# Altium schematic sheet 2_CON_FMC.SchDoc
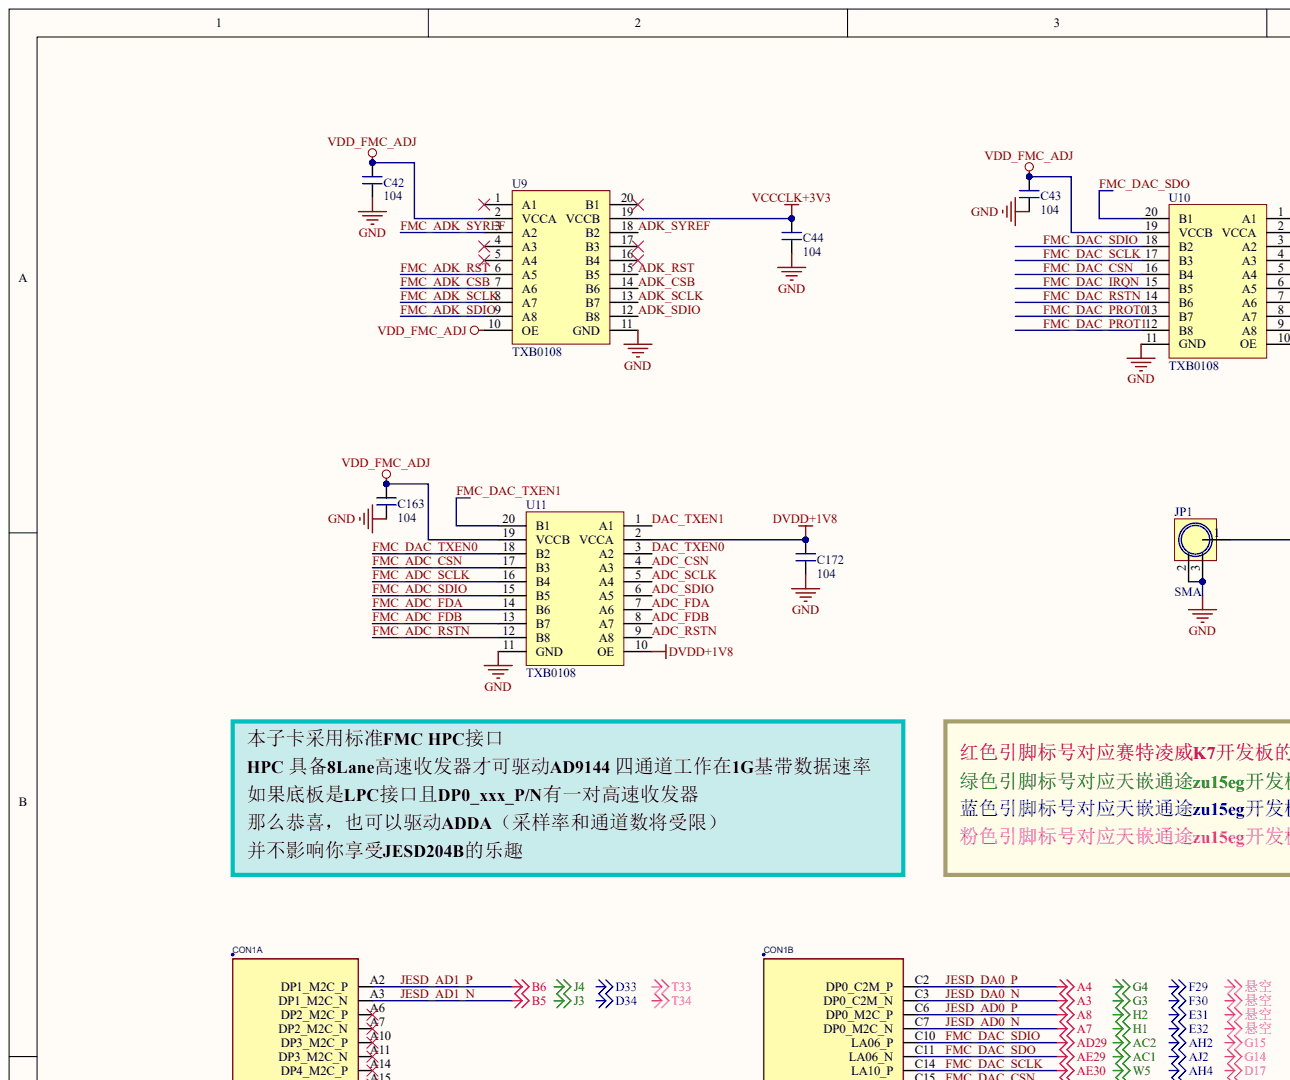
[diagram p0: 2_CON_FMC.SchDoc, top left]
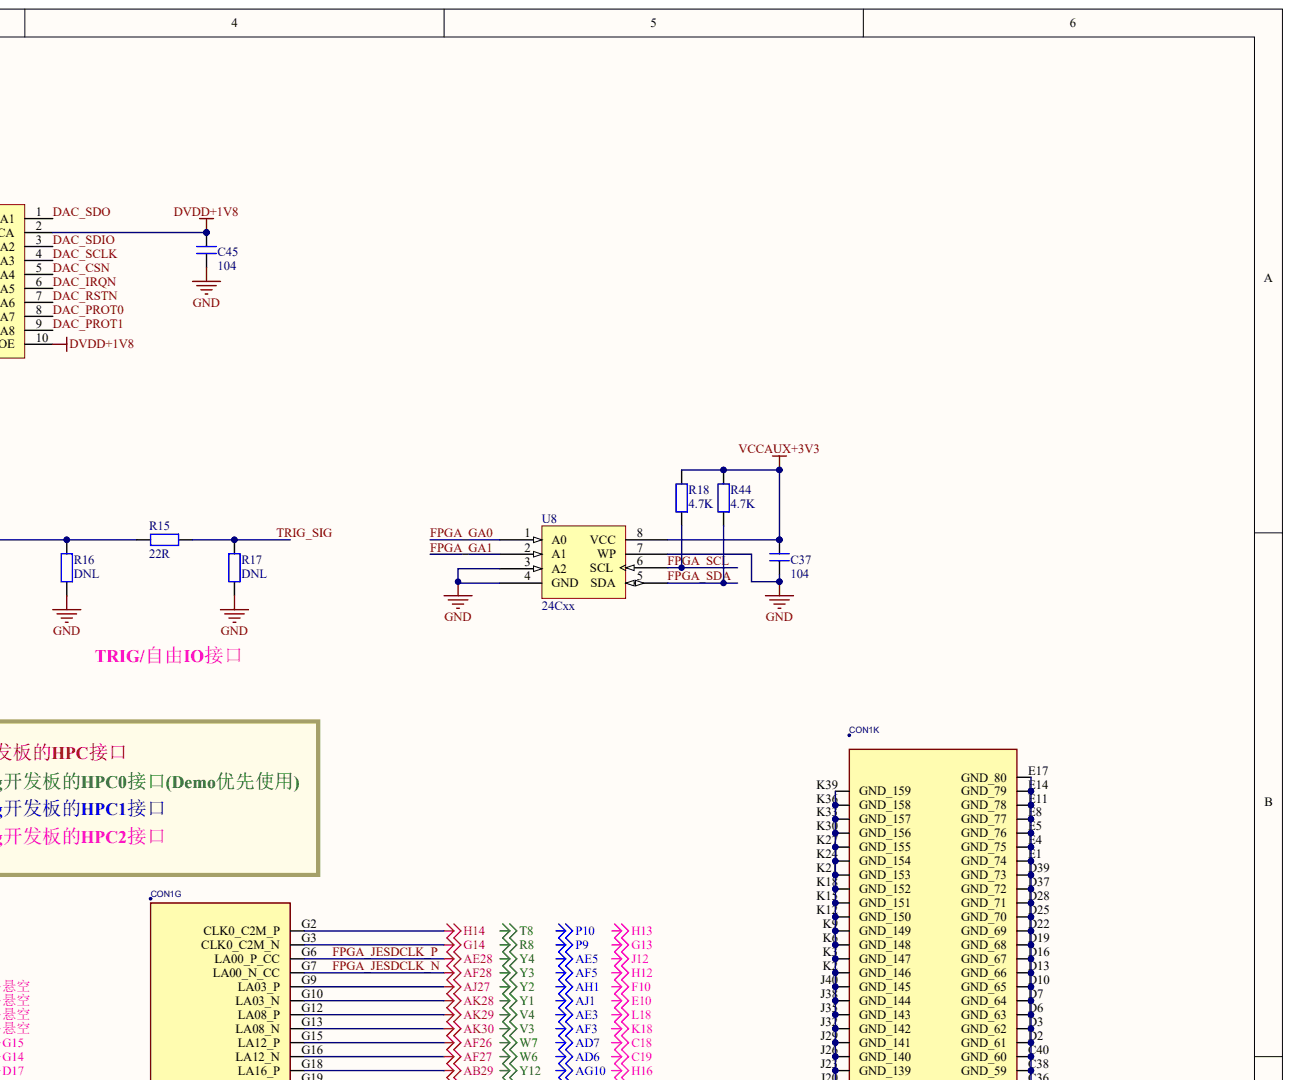
[diagram p1: 2_CON_FMC.SchDoc, top right]
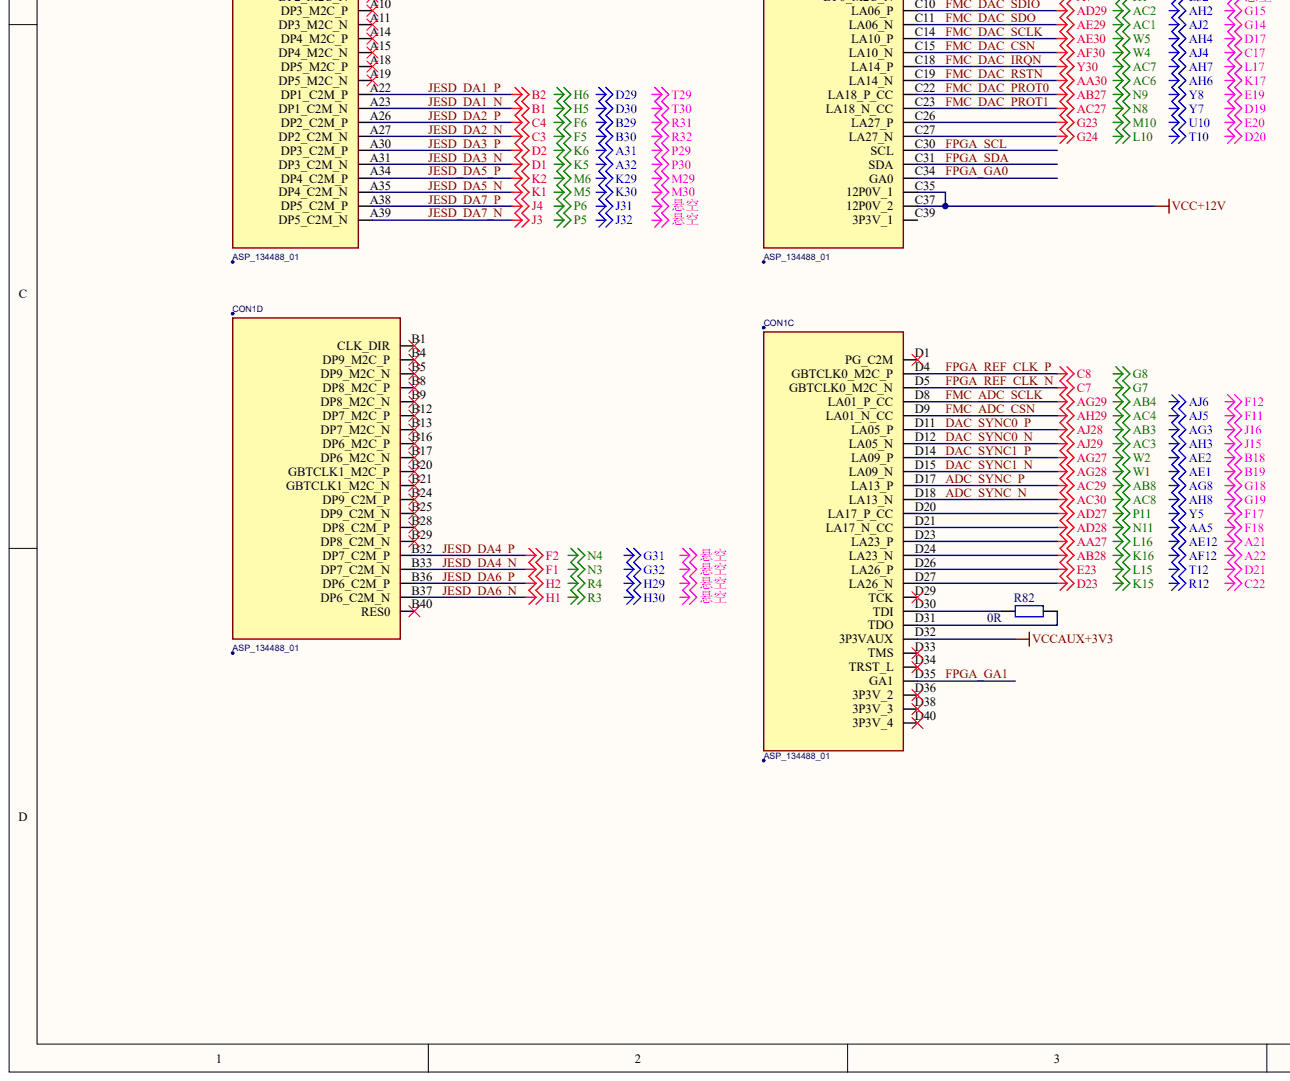
[diagram p2: 2_CON_FMC.SchDoc, bottom left]
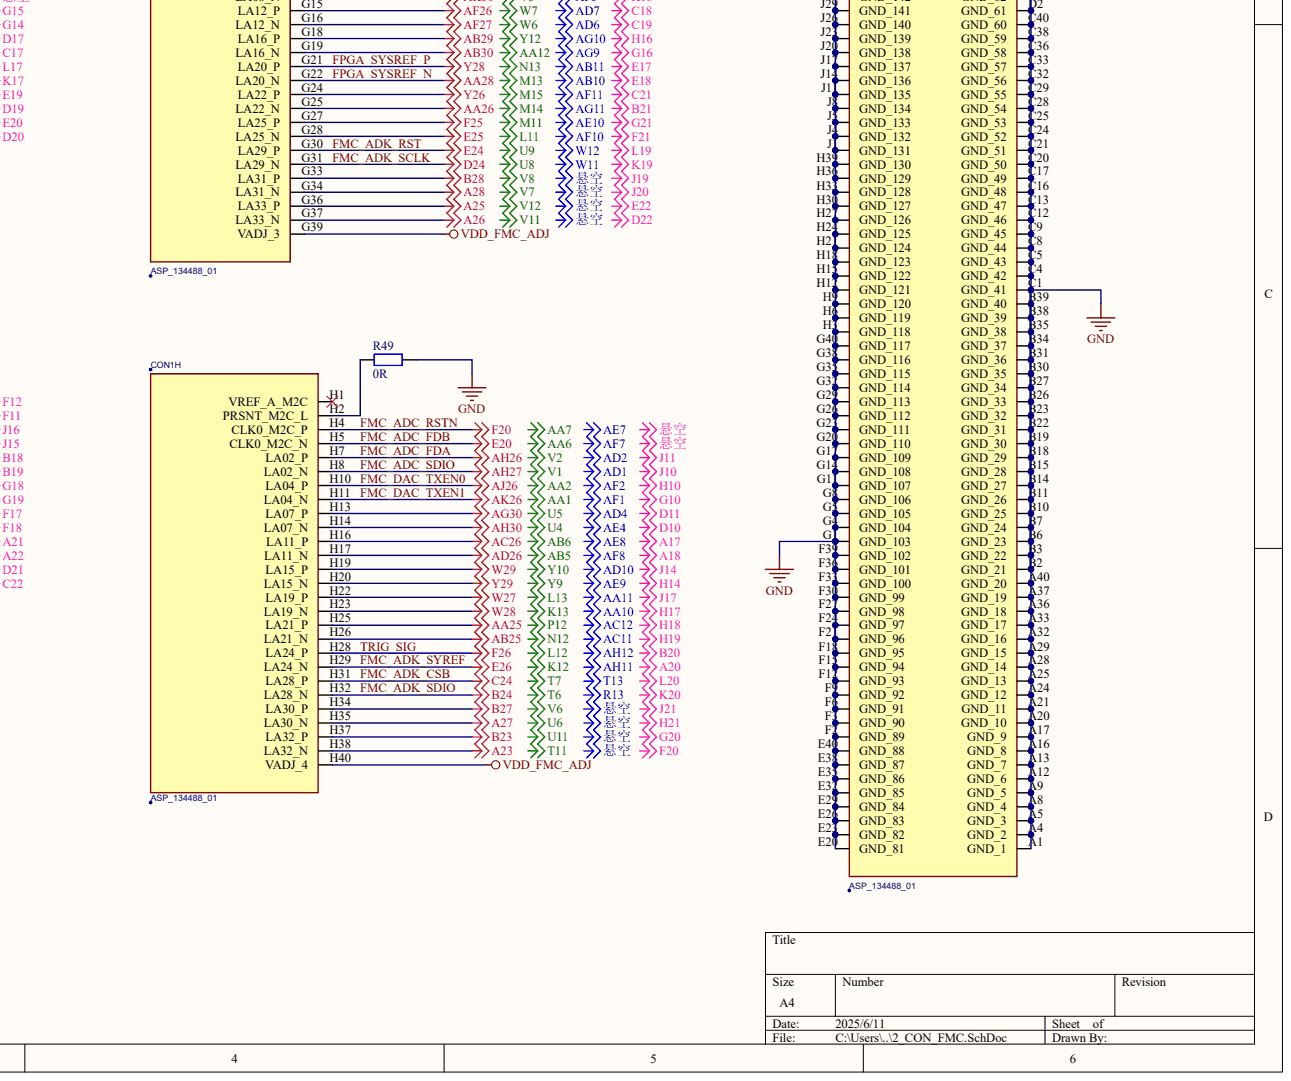
[diagram p3: 2_CON_FMC.SchDoc, bottom right]

## Parts
C37  104
C42  104
C43  104
C44  104
C45  104
C163  104
C172  104
CON1  ASP_134488_01  (parts A,B,C,D,G,H,K)
JP1  SMA
R15  22R
R16  DNL
R17  DNL
R18  4.7K
R44  4.7K
R49  0R
R82  0R
U8  24Cxx
U9  TXB0108
U10  TXB0108
U11  TXB0108

## Nets
A23: CON1.H38(LA32_N)
A25: CON1.G36(LA33_P)
A26: CON1.G37(LA33_N)
A27: CON1.H35(LA30_N)
A28: CON1.G34(LA31_N)
AA25: CON1.H25(LA21_P)
AA26: CON1.G25(LA22_N)
AA27: CON1.D23(LA23_P)
AB25: CON1.H26(LA21_N)
AB28: CON1.D24(LA23_N)
AB29: CON1.G18(LA16_P)
AB30: CON1.G19(LA16_N)
AC26: CON1.H16(LA11_P)
AD26: CON1.H17(LA11_N)
AD27: CON1.D20(LA17_P_CC)
AD28: CON1.D21(LA17_N_CC)
AF26: CON1.G15(LA12_P)
AF27: CON1.G16(LA12_N)
AG30: CON1.H13(LA07_P)
AH30: CON1.H14(LA07_N)
AJ27: CON1.G9(LA03_P)
AK28: CON1.G10(LA03_N)
AK29: CON1.G12(LA08_P)
AK30: CON1.G13(LA08_N)
B23: CON1.H37(LA32_P)
B27: CON1.H34(LA30_P)
B28: CON1.G33(LA31_P)
D23: CON1.D27(LA26_N)
DVDD+1V8: C45.1 C172.1 U10.2(VCCA) U10.10(OE) U11.2(VCCA) U11.10(OE)
E23: CON1.D26(LA26_P)
E25: CON1.G28(LA25_N)
F25: CON1.G27(LA25_P)
G14: CON1.G3(CLK0_C2M_N)
G23: CON1.C26(LA27_P)
G24: CON1.C27(LA27_N)
GND: C37.2 C42.2 C43.2 C44.2 C45.2 C163.2 C172.2 CON1.A1(GND_1) CON1.A4(GND_2) CON1.A5(GND_3) CON1.A8(GND_4) CON1.A9(GND_5) CON1.A12(GND_6) CON1.A13(GND_7) CON1.A16(GND_8) CON1.A17(GND_9) CON1.A20(GND_10) CON1.A21(GND_11) CON1.A24(GND_12) CON1.A25(GND_13) CON1.A28(GND_14) CON1.A29(GND_15) CON1.A32(GND_16) CON1.A33(GND_17) CON1.A36(GND_18) CON1.A37(GND_19) CON1.A40(GND_20) CON1.B2(GND_21) CON1.B3(GND_22) CON1.B6(GND_23) CON1.B7(GND_24) CON1.B10(GND_25) CON1.B11(GND_26) CON1.B14(GND_27) CON1.B15(GND_28) CON1.B18(GND_29) CON1.B19(GND_30) CON1.B22(GND_31) CON1.B23(GND_32) CON1.B26(GND_33) CON1.B27(GND_34) CON1.B30(GND_35) CON1.B31(GND_36) CON1.B34(GND_37) CON1.B35(GND_38) CON1.B38(GND_39) CON1.B39(GND_40) CON1.C1(GND_41) CON1.C4(GND_42) CON1.C5(GND_43) CON1.C8(GND_44) CON1.C9(GND_45) CON1.C12(GND_46) CON1.C13(GND_47) CON1.C16(GND_48) CON1.C17(GND_49) CON1.C20(GND_50) CON1.C21(GND_51) CON1.C24(GND_52) CON1.C25(GND_53) CON1.C28(GND_54) CON1.C29(GND_55) CON1.C32(GND_56) CON1.C33(GND_57) CON1.C36(GND_58) CON1.C38(GND_59) CON1.C40(GND_60) CON1.D2(GND_61) CON1.D3(GND_62) CON1.D6(GND_63) CON1.D7(GND_64) CON1.D10(GND_65) CON1.D13(GND_66) CON1.D16(GND_67) CON1.D19(GND_68) CON1.D22(GND_69) CON1.D25(GND_70) CON1.D28(GND_71) CON1.D37(GND_72) CON1.D39(GND_73) CON1.E1(GND_74) CON1.E4(GND_75) CON1.E5(GND_76) CON1.E8(GND_77) CON1.E11(GND_78) CON1.E14(GND_79) CON1.E17(GND_80) CON1.E20(GND_81) CON1.E23(GND_82) CON1.E26(GND_83) CON1.E29(GND_84) CON1.E32(GND_85) CON1.E35(GND_86) CON1.E38(GND_87) CON1.E40(GND_88) CON1.F2(GND_89) CON1.F3(GND_90) CON1.F6(GND_91) CON1.F9(GND_92) CON1.F12(GND_93) CON1.F15(GND_94) CON1.F18(GND_95) CON1.F21(GND_96) CON1.F24(GND_97) CON1.F27(GND_98) CON1.F30(GND_99) CON1.F33(GND_100) CON1.F36(GND_101) CON1.F39(GND_102) CON1.G1(GND_103) CON1.G4(GND_104) CON1.G5(GND_105) CON1.G8(GND_106) CON1.G11(GND_107) CON1.G14(GND_108) CON1.G17(GND_109) CON1.G20(GND_110) CON1.G23(GND_111) CON1.G26(GND_112) CON1.G29(GND_113) CON1.G32(GND_114) CON1.G35(GND_115) CON1.G38(GND_116) CON1.G40(GND_117) CON1.H3(GND_118) CON1.H6(GND_119) CON1.H9(GND_120) CON1.H12(GND_121) CON1.H15(GND_122) CON1.H18(GND_123) CON1.H21(GND_124) CON1.H24(GND_125) CON1.H27(GND_126) CON1.H30(GND_127) CON1.H33(GND_128) CON1.H36(GND_129) CON1.H39(GND_130) CON1.J1(GND_131) CON1.J4(GND_132) CON1.J5(GND_133) CON1.J8(GND_134) CON1.J11(GND_135) CON1.J14(GND_136) CON1.J17(GND_137) CON1.J20(GND_138) CON1.J23(GND_139) CON1.J26(GND_140) CON1.J29(GND_141) CON1.J32(GND_142) CON1.J35(GND_143) CON1.J38(GND_144) CON1.J40(GND_145) CON1.K2(GND_146) CON1.K3(GND_147) CON1.K6(GND_148) CON1.K9(GND_149) CON1.K12(GND_150) CON1.K15(GND_151) CON1.K18(GND_152) CON1.K21(GND_153) CON1.K24(GND_154) CON1.K27(GND_155) CON1.K30(GND_156) CON1.K33(GND_157) CON1.K36(GND_158) CON1.K39(GND_159) JP1.2 JP1.3 R16.2 R17.2 R49.2 U8.3(A2) U8.4(GND) U8.7(WP) U9.11(GND) U9.21 U10.11(GND) U10.21 U11.11(GND) U11.21
H14: CON1.G2(CLK0_C2M_P)
VCC+12V: CON1.C35(12P0V_1) CON1.C37(12P0V_2)
VCCAUX+3V3: C37.1 CON1.D32(3P3VAUX) R18.1 R44.1 U8.8(VCC)
VCCCLK+3V3: C44.1 U9.19(VCCB)
VDD_FMC_ADJ: C42.1 C43.1 C163.1 CON1.G39(VADJ_3) CON1.H40(VADJ_4) U9.2(VCCA) U9.10(OE) U10.19(VCCB) U11.19(VCCB)
W27: CON1.H22(LA19_P)
W28: CON1.H23(LA19_N)
W29: CON1.H19(LA15_P)
Y26: CON1.G24(LA22_P)
Y29: CON1.H20(LA15_N)
ADC_CSN: U11.4(A3)
ADC_FDA: U11.7(A6)
ADC_FDB: U11.8(A7)
ADC_RSTN: U11.9(A8)
ADC_SCLK: U11.5(A4)
ADC_SDIO: U11.6(A5)
ADC_SYNC_N: CON1.D18(LA13_N)
ADC_SYNC_P: CON1.D17(LA13_P)
ADK_CSB: U9.14(B6)
ADK_RST: U9.15(B5)
ADK_SCLK: U9.13(B7)
ADK_SDIO: U9.12(B8)
ADK_SYREF: U9.18(B2)
DAC_CSN: U10.5(A4)
DAC_IRQN: U10.6(A5)
DAC_PROT0: U10.8(A7)
DAC_PROT1: U10.9(A8)
DAC_RSTN: U10.7(A6)
DAC_SCLK: U10.4(A3)
DAC_SDIO: U10.3(A2)
DAC_SDO: U10.1(A1)
DAC_SYNC0_N: CON1.D12(LA05_N)
DAC_SYNC0_P: CON1.D11(LA05_P)
DAC_SYNC1_N: CON1.D15(LA09_N)
DAC_SYNC1_P: CON1.D14(LA09_P)
DAC_TXEN0: U11.3(A2)
DAC_TXEN1: U11.1(A1)
FMC_ADC_CSN: CON1.D9(LA01_N_CC) U11.17(B3)
FMC_ADC_FDA: CON1.H7(LA02_P) U11.14(B6)
FMC_ADC_FDB: CON1.H5(CLK0_M2C_N) U11.13(B7)
FMC_ADC_RSTN: CON1.H4(CLK0_M2C_P) U11.12(B8)
FMC_ADC_SCLK: CON1.D8(LA01_P_CC) U11.16(B4)
FMC_ADC_SDIO: CON1.H8(LA02_N) U11.15(B5)
FMC_ADK_CSB: CON1.H31(LA28_P) U9.7(A6)
FMC_ADK_RST: CON1.G30(LA29_P) U9.6(A5)
FMC_ADK_SCLK: CON1.G31(LA29_N) U9.8(A7)
FMC_ADK_SDIO: CON1.H32(LA28_N) U9.9(A8)
FMC_ADK_SYREF: CON1.H29(LA24_N) U9.3(A2)
FMC_DAC_CSN: CON1.C15(LA10_N) U10.16(B4)
FMC_DAC_IRQN: CON1.C18(LA14_P) U10.15(B5)
FMC_DAC_PROT0: CON1.C22(LA18_P_CC) U10.13(B7)
FMC_DAC_PROT1: CON1.C23(LA18_N_CC) U10.12(B8)
FMC_DAC_RSTN: CON1.C19(LA14_N) U10.14(B6)
FMC_DAC_SCLK: CON1.C14(LA10_P) U10.17(B3)
FMC_DAC_SDIO: CON1.C10(LA06_P) U10.18(B2)
FMC_DAC_SDO: CON1.C11(LA06_N) U10.20(B1)
FMC_DAC_TXEN0: CON1.H10(LA04_P) U11.18(B2)
FMC_DAC_TXEN1: CON1.H11(LA04_N) U11.20(B1)
FPGA_GA0: CON1.C34(GA0) U8.1(A0)
FPGA_GA1: CON1.D35(GA1) U8.2(A1)
FPGA_JESDCLK_N: CON1.G7(LA00_N_CC)
FPGA_JESDCLK_P: CON1.G6(LA00_P_CC)
FPGA_REF_CLK_N: CON1.D5(GBTCLK0_M2C_N)
FPGA_REF_CLK_P: CON1.D4(GBTCLK0_M2C_P)
FPGA_SCL: CON1.C30(SCL) R18.2 U8.6(SCL)
FPGA_SDA: CON1.C31(SDA) R44.2 U8.5(SDA)
FPGA_SYSREF_N: CON1.G22(LA20_N)
FPGA_SYSREF_P: CON1.G21(LA20_P)
JESD_AD0_N: CON1.C7(DP0_M2C_N)
JESD_AD0_P: CON1.C6(DP0_M2C_P)
JESD_AD1_N: CON1.A3(DP1_M2C_N)
JESD_AD1_P: CON1.A2(DP1_M2C_P)
JESD_DA0_N: CON1.C3(DP0_C2M_N)
JESD_DA0_P: CON1.C2(DP0_C2M_P)
JESD_DA1_N: CON1.A23(DP1_C2M_N)
JESD_DA1_P: CON1.A22(DP1_C2M_P)
JESD_DA2_N: CON1.A27(DP2_C2M_N)
JESD_DA2_P: CON1.A26(DP2_C2M_P)
JESD_DA3_N: CON1.A31(DP3_C2M_N)
JESD_DA3_P: CON1.A30(DP3_C2M_P)
JESD_DA4_N: CON1.B33(DP7_C2M_N)
JESD_DA4_P: CON1.B32(DP7_C2M_P)
JESD_DA5_N: CON1.A35(DP4_C2M_N)
JESD_DA5_P: CON1.A34(DP4_C2M_P)
JESD_DA6_N: CON1.B37(DP6_C2M_N)
JESD_DA6_P: CON1.B36(DP6_C2M_P)
JESD_DA7_N: CON1.A39(DP5_C2M_N)
JESD_DA7_P: CON1.A38(DP5_C2M_P)
TRIG_SIG: CON1.H28(LA24_P) R15.2 R17.1
NetCON1_D30: CON1.D30(TDI) R82.1
NetCON1_D31: CON1.D31(TDO) R82.2
NetCON1_H2: CON1.H2(PRSNT_M2C_L) R49.1
NetJP1_1: JP1.1 R15.1 R16.1
A17:
A18:
A20:
A21:
A22:
A31:
A32:
AA1:
AA2:
AA5:
AA6:
AA7:
AA10:
AA11:
AA12:
AB3:
AB4:
AB5:
AB6:
AB8:
AB10:
AB11:
AC1:
AC2:
AC3:
AC4:
AC6:
AC7:
AC8:
AC11:
AC12:
AD1:
AD2:
AD4:
AD6:
AD7:
AD10:
AE1:
AE2:
AE3:
AE4:
AE5:
AE7:
AE8:
AE9:
AE10:
AE12:
AF1:
AF2:
AF3:
AF5:
AF7:
AF8:
AF10:
AF11:
AF12:
AG3:
AG8:
AG9:
AG10:
AG11:
AH1:
AH2:
AH3:
AH4:
AH6:
AH7:
AH8:
AH11:
AH12:
AJ1:
AJ2:
AJ4:
AJ5:
AJ6:
B18:
B19:
B20:
B21:
B29:
B30:
C17:
C18:
C19:
C21:
C22:
D10:
D11:
D17:
D19:
D20:
D21:
D22:
D29:
D30:
D33:
D34:
E10:
E17:
E18:
E19:
E22:
E31:
E32:
F5:
F6:
F10:
F11:
F12:
F17:
F18:
F21:
F29:
F30:
G3:
G4:
G7:
G8:
G10:
G13:
G15:
G16:
G18:
G19:
G20:
G21:
G31:
G32:
H5:
H6:
H10:
H12:
H13:
H16:
H17:
H18:
H19:
H21:
H29:
H30:
J10:
J11:
J12:
J14:
J15:
J16:
J17:
J19:
J20:
J21:
J31:
J32:
K5:
K6:
K12:
K13:
K15:
K16:
K17:
K18:
K19:
K20:
K29:
K30:
L10:
L11:
L12:
L13:
L15:
L16:
L17:
L18:
L19:
L20:
M5:
M6:
M10:
M11:
M13:
M14:
M15:
M29:
M30:
N3:
N4:
N8:
N9:
N11:
N12:
N13:
P5:
P6:
P9:
P10:
P11:
P12:
P29:
P30:
R3:
R4:
R8:
R12:
R13:
R31:
R32:
T6:
T7:
T8:
T10:
T11:
T12:
T13:
T29:
T30:
T33:
T34:
U4:
U5:
U6:
U8:
U9:
U10:
U11:
V1:
V2:
V3:
V4:
V6:
V7:
V8:
V11:
V12:
W1:
W2:
W4:
W5:
W6:
W7:
W11:
W12:
Y1:
Y2:
Y3:
Y4:
Y5:
Y7:
Y8:
Y9:
Y10:
Y12:
悬空:

Not connected: CON1 A6(DP2_M2C_P) A7(DP2_M2C_N) A10(DP3_M2C_P) A11(DP3_M2C_N) A14(DP4_M2C_P) A15(DP4_M2C_N) A18(DP5_M2C_P) A19(DP5_M2C_N) B1(CLK_DIR) B4(DP9_M2C_P) B5(DP9_M2C_N) B8(DP8_M2C_P) B9(DP8_M2C_N) B12(DP7_M2C_P) B13(DP7_M2C_N) B16(DP6_M2C_P) B17(DP6_M2C_N) B20(GBTCLK1_M2C_P) B21(GBTCLK1_M2C_N) B24(DP9_C2M_P) B25(DP9_C2M_N) B28(DP8_C2M_P) B29(DP8_C2M_N) B40(RES0) C39(3P3V_1) D1(PG_C2M) D29(TCK) D33(TMS) D34(TRST_L) D36(3P3V_2) D38(3P3V_3) D40(3P3V_4) H1(VREF_A_M2C); U9 1(A1) 4(A3) 5(A4) 16(B4) 17(B3) 20(B1)

## Library data of the parts
CON1 = (no symbol name): Manufacturer PartNumber: ASP_134486_01; Manufacturer: SAMTEC
JP1 = (no symbol name): BOM名称: SMA-2.4G射频天线接口; 唯一出售店铺网址: https://shop463601444.taobao.com
R15-R18 R44 R49 R82 = (no symbol name): BOM名称: 贴片电阻; : 联系淘宝ID：黄晶宝石，获取更新版封装库; : 唯一出售店铺网址https://shop463601444.taobao.com
U8 = (no symbol name): BOM名称: 外置EEPROM; 卖家免费技术支持，联系售后: 唯一出售店铺网址https://shop463601444.taobao.com; : 联系淘宝ID：黄晶宝石，获取更新版封装库; : 其他店铺购入均为假冒，请立即申请退款，本店奖励2~5元现金
C37 C42-C45 C163 C172 U9-U11 = (no symbol name)
  C37 C42-C45 C163 C172: : 联系淘宝ID：黄晶宝石，获取更新版封装库; : 唯一出售店铺网址https://shop463601444.taobao.com
